# Altium schematic sheet Micro.SchDoc
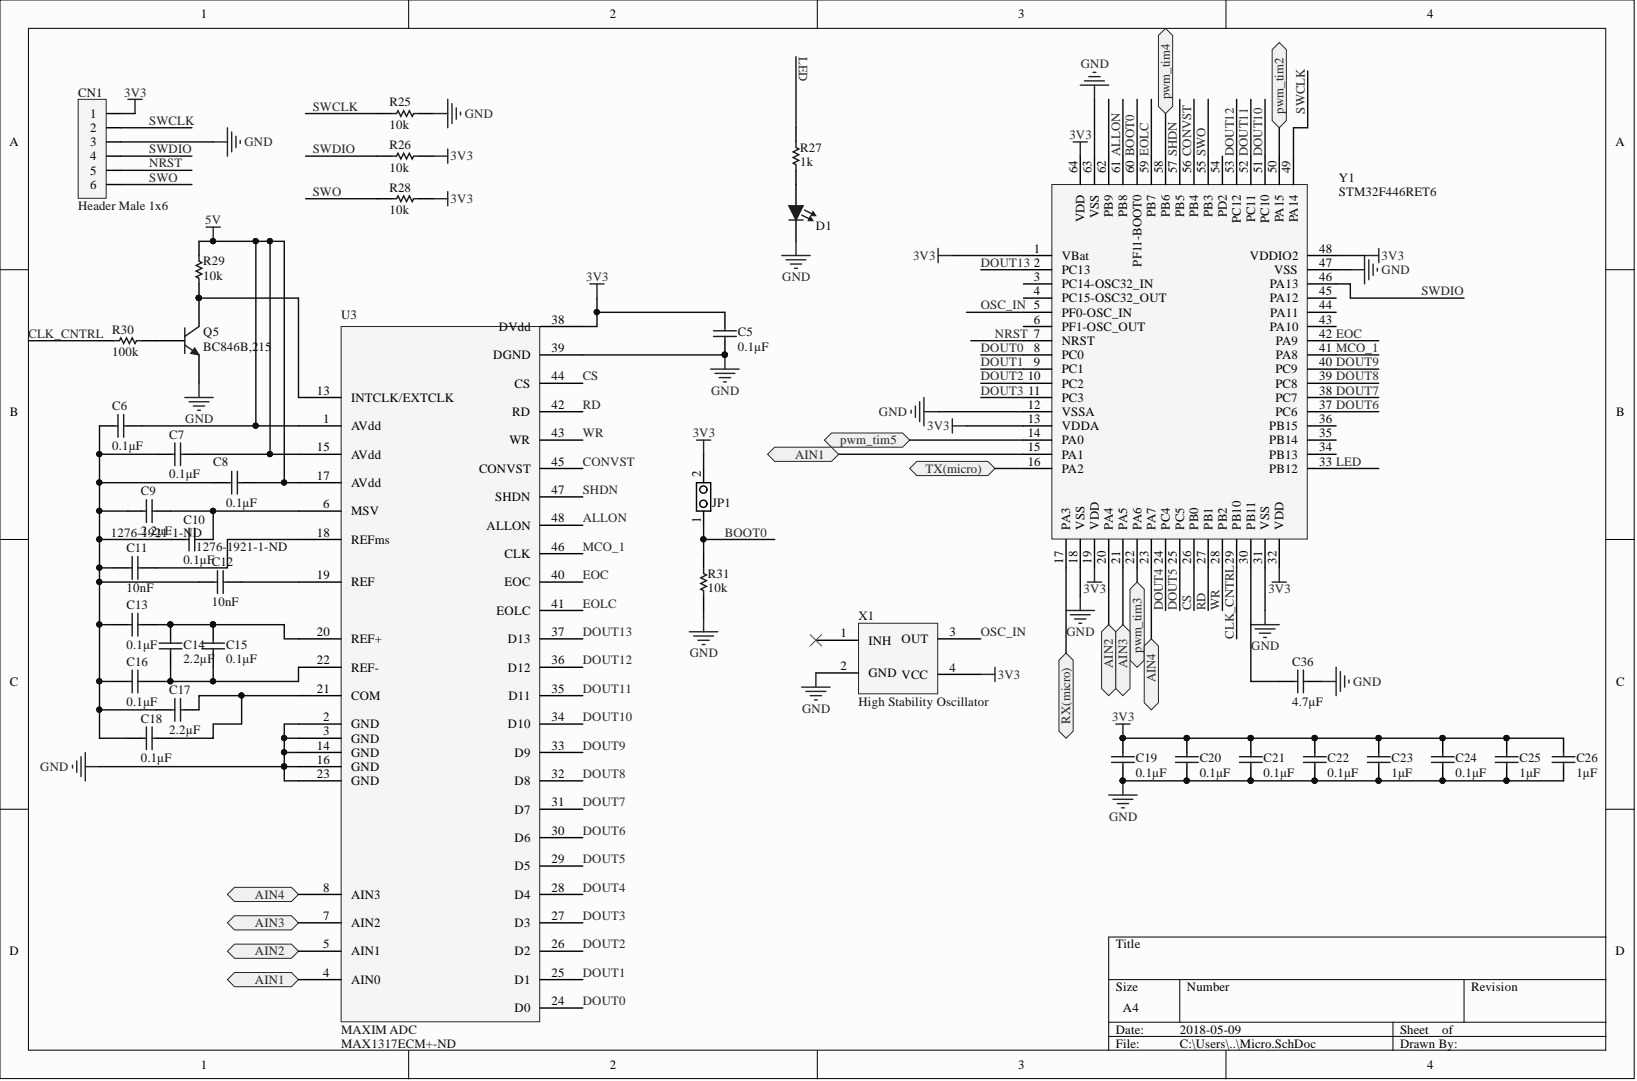
[diagram p0: Micro.SchDoc]

## Parts
C5  0.1μF  [CAPC1608N(0603")]
C6  0.1μF  [CAPC1608N(0603")]
C7  0.1μF  [CAPC1608N(0603")]
C8  0.1μF  [CAPC1608N(0603")]
C9  2.2µF  [CAPC1608N(0603")]
C10  0.1μF  [CAPC1608N(0603")]
C11  10nF  Supplier Part Number 1: 1276-1921-1-ND  [CAPC1608N(0603")]
C12  10nF  Supplier Part Number 1: 1276-1921-1-ND  [CAPC1608N(0603")]
C13  0.1μF  [CAPC1608N(0603")]
C14  2.2µF  [CAPC1608N(0603")]
C15  0.1μF  [CAPC1608N(0603")]
C16  0.1μF  [CAPC1608N(0603")]
C17  2.2µF  [CAPC1608N(0603")]
C18  0.1μF  [CAPC1608N(0603")]
C19  0.1μF  [CAPC1608N(0603")]
C20  0.1μF  [CAPC1608N(0603")]
C21  0.1μF  [CAPC1608N(0603")]
C22  0.1μF  [CAPC1608N(0603")]
C23  1μF  [CAPC1608N(0603")]
C24  0.1μF  [CAPC1608N(0603")]
C25  1μF  [CAPC1608N(0603")]
C26  1μF  [CAPC1608N(0603")]
C36  4.7μF  [CAPC1608N(0603")]
CN1  Header Male 1x6  [Header, Male, 1X6]
D1  Red  [LED0603]  (value not drawn)
JP1  1X2 Pin Head  [2X1 Males Header]  (value not drawn)
Q5  BC846B,215  [SOT-23]
R25  10k  [RESC1608N(0603")]
R26  10k  [RESC1608N(0603")]
R27  1k  [RESC1608N(0603")]
R28  10k  [RESC1608N(0603")]
R29  10k  [RESC1608N(0603")]
R30  100k  [RESC1608N(0603")]
R31  10k  [RESC1608N(0603")]
U3  MAXIM ADC  Supplier Part Number 1: MAX1317ECM+-ND  [LQFP-48]
X1  High Stability Oscillator  [KC2520C-C2 SOP]
Y1  STM32F446RET6  [STM-LQFP64_M]

## Ports
AIN1, AIN2, AIN3, AIN4, pwm_tim2, pwm_tim3, pwm_tim4, pwm_tim5, RX(micro), TX(micro)

## Nets
3V3: C5.2 C19.2 C20.2 C21.2 C22.2 C23.2 C24.2 C25.2 C26.2 CN1.1 JP1.2 R26.2 R28.2 U3.38(DVdd) X1.4(VCC) Y1.1(VBat) Y1.13(VDDA) Y1.19(VDD) Y1.32(VDD) Y1.48(VDDIO2) Y1.64(VDD)
5V: C6.2 C7.2 C8.2 R29.2 U3.1(AVdd) U3.15(AVdd) U3.17(AVdd)
GND: C5.1 C19.1 C20.1 C21.1 C22.1 C23.1 C24.1 C25.1 C26.1 C36.2 CN1.3 D1.1 Q5.2 R25.1 R31.1 U3.2(GND) U3.3(GND) U3.14(GND) U3.16(GND) U3.23(GND) U3.39(DGND) X1.2(GND) Y1.12(VSSA) Y1.18(VSS) Y1.31(VSS) Y1.47(VSS) Y1.63(VSS)
AIN1: U3.4(AIN0) Y1.15(PA1) ; port AIN1
AIN2: U3.5(AIN1) Y1.20(PA4) ; port AIN2
AIN3: U3.7(AIN2) Y1.21(PA5) ; port AIN3
AIN4: U3.8(AIN3) Y1.23(PA7) ; port AIN4
ALLON: U3.48(ALLON) Y1.61(PB8)
BOOT0: JP1.1 R31.2 Y1.60(PF11-BOOT0)
CLK_CNTRL: R30.1 Y1.29(PB10)
CONVST: U3.45(CONVST) Y1.56(PB4)
CS: U3.44(CS) Y1.26(PB0)
DOUT0: U3.24(D0) Y1.8(PC0)
DOUT1: U3.25(D1) Y1.9(PC1)
DOUT2: U3.26(D2) Y1.10(PC2)
DOUT3: U3.27(D3) Y1.11(PC3)
DOUT4: U3.28(D4) Y1.24(PC4)
DOUT5: U3.29(D5) Y1.25(PC5)
DOUT6: U3.30(D6) Y1.37(PC6)
DOUT7: U3.31(D7) Y1.38(PC7)
DOUT8: U3.32(D8) Y1.39(PC8)
DOUT9: U3.33(D9) Y1.40(PC9)
DOUT10: U3.34(D10) Y1.51(PC10)
DOUT11: U3.35(D11) Y1.52(PC11)
DOUT12: U3.36(D12) Y1.53(PC12)
DOUT13: U3.37(D13) Y1.2(PC13)
EOC: U3.40(EOC) Y1.42(PA9)
EOLC: U3.41(EOLC) Y1.59(PB7)
LED: R27.2 Y1.33(PB12)
MCO_1: U3.46(CLK) Y1.41(PA8)
NRST: CN1.5 Y1.7(NRST)
OSC_IN: X1.3(OUT) Y1.5(PF0-OSC_IN)
pwm_tim2: Y1.50(PA15) ; port pwm_tim2
pwm_tim3: Y1.22(PA6) ; port pwm_tim3
pwm_tim4: Y1.58(PB6) ; port pwm_tim4
pwm_tim5: Y1.14(PA0) ; port pwm_tim5
RD: U3.42(RD) Y1.27(PB1)
RX(micro): Y1.17(PA3) ; port RX(micro)
SHDN: U3.47(SHDN) Y1.57(PB5)
SWCLK: CN1.2 R25.2 Y1.49(PA14)
SWDIO: CN1.4 R26.1 Y1.46(PA13)
SWO: CN1.6 R28.1 Y1.55(PB3)
TX(micro): Y1.16(PA2) ; port TX(micro)
WR: U3.43(WR) Y1.28(PB2)
NetC6_1: C6.1 C7.1 C8.1 C9.1 C10.1 C11.1 C12.1 C13.2 C16.2 C17.1 C18.1
NetC9_2: C9.2 C10.2 U3.6(MSV)
NetC11_2: C11.2 U3.18(REFms)
NetC12_2: C12.2 U3.19(REF)
NetC13_1: C13.1 C14.2 C15.2 U3.20(REF+)
NetC14_1: C14.1 C15.1 C16.1 U3.22(REF-)
NetC17_2: C17.2 C18.2 U3.21(COM)
NetC36_1: C36.1 Y1.30(PB11)
NetD1_2: D1.2 R27.1
NetQ5_1: Q5.1 R30.2
NetQ5_3: Q5.3 R29.1 U3.13(INTCLK/EXTCLK)

Not connected: X1 1(INH); Y1 3(PC14-OSC32_IN) 4(PC15-OSC32_OUT) 6(PF1-OSC_OUT) 34(PB13) 35(PB14) 36(PB15) 43(PA10) 44(PA11) 45(PA12) 54(PD2) 62(PB9)

## Library data of the parts
C5-C8 C10 C13 C15 C16 C18-C22 C24 = 0.1uF 100V 0603 SMD, Ceramic: Capacitor, Ceramic, 100V, +/- 10%, 0603 SMD, X7R; MFG: TDK; MFG#: CGA3E3X7S2A104K080AB; Component Kind: Standard; PackageDescription: Chip Capacitor; Published: 16-04-26; Supplier 1: Digi-Key; Supplier Part Number 1: 445-6938-1-ND; Value: 0.1μF; Created By: Ashwin Sira; Library Name: AUVic_Integrated_Library_Capacitors.IntLib; Publisher: AUVic
C9 C14 C17 = 2.2uF, 50V, 0603 SMD, X5R, Ceramic: Capacitor, Ceramic, 50V, 10%, 0603 SMD, X5R; Component Kind: Standard; PackageDescription: Chip Capacitor; Supplier 1: Digi-Key; Supplier Part Number 1: 490-10733-1-ND; Value: 2.2µF; Library Name: AUVic_Integrated_Library_Capacitors.IntLib; Publisher: AUVic
C11 C12 = 10 nF, 50V 0603 SMD, Ceramic: Capacitor, Ceramic, 50V, +/- 10%, 0603 SMD; MFG: Samsung; MFG#: GRM188R61A475KE15D; Component Kind: Standard; PackageDescription: Chip Capacitor; Published: 16-06-23; Supplier 1: Digi-Key; Value: 10nF; Created By: Gabriel; Library Name: AUVic_Integrated_Library_Capacitors.IntLib; Publisher: AUVic
C23 C25 C26 = 1uF 25V 0603 SMD, Ceramic: Capacitor, Ceramic, 25V, +/- 10%, 0603 SMD, X5R; MFG: Murata-Electronics-North-America; MFG#: GRM188R61E105KA12D; Component Kind: Standard; PackageDescription: Chip Capacitor; Published: 16-06-27; Supplier 1: Digi-Key; Supplier Part Number 1: 490-3897-1-ND; Value: 1μF; Created By: Andy Bates; Library Name: AUVic_Integrated_Library_Capacitors.IntLib; Publisher: AUVic
C36 = 4.7uF 10V 0603 SMD, Ceramic: Capacitor, Ceramic, 10V, +/- 10%, 0603 SMD, X5R; MFG: Samsung; MFG#: GRM188R61A475KE15D; Component Kind: Standard; PackageDescription: Chip Capacitor; Published: 16-06-23; Supplier 1: Digi-Key; Supplier Part Number 1: 490-10477-1-ND; Value: 4.7μF; Created By: Ashwin Sira; Library Name: AUVic_Integrated_Library_Capacitors.IntLib; Publisher: AUVic
CN1 = Header, Male, 1x6: 1x6, Pads Pitch: 0.1" (2.54mm), Through hole; Made By: Andy Bates; Component Kind: Standard; Supplier 1: Digi-Key; Supplier Part Number 1: 952-2270-ND; Library Name: AUVic_Schematic_Library_Connectors.SchLib
D1 = LED, 0805 (2012), Red, Clear: Colour: Red; Component Kind: Standard; Description: LED, 0805 (2012), Red, Clear; Supplier 1: Digi-Key; Supplier Part Number 1: 732-4984-1-ND; Created By: Ashwin Sira; Library Name: AUVic_Integrated_Library_Diodes.IntLib
JP1 = 1x2 Male Header: Made By: Andy Bates; Component Kind: Standard; Supplier 1: Digi-Key; Supplier Part Number 1: 952-3073-ND; Library Name: AUVic_Schematic_Library_Connectors.SchLib
Q5 = BC846B,215: NPN 65V 100mA 250mW; Component Kind: Standard; DatasheetVersion: 1997; LatestRevisionDate: 09-Sep-2016; Published: 8-Jun-2000; Supplier 1: Digi-Key; Supplier Part Number 1: 568-1632-1-ND; Library Name: AUVic_Integrated_Library_Transistors.IntLib; Publisher: Andy Bates
R25 R26 R28 R29 R31 = 10kR, 1%, 1/10W, 0603 SMD: Made By: Ashwin Sira; Owned By: AUVic; Component Kind: Standard; Created On: 14-05-31; Description: 10kR, 1%, 1/10W, 0603 SMD; Last Edited: 14-05-31; Library Name: AUVic_Integrated_Library_Resistors.IntLib; Supplier 1: Digi-Key; Supplier Part Number 1: 311-10.0KHRCT-ND; Value: 10k
R27 = 1kR, 1%, 1/10W , 0603, SMD: Component Kind: Standard; Date Created: 2017-05-10; Description: 1kR, 1%, 1/10W , 0603, SMD; Supplier 1: Digikey; Value: 1k; Created By: Andy Bates; Library Name: AUVic_Integrated_Library_Resistors.IntLib; Publisher: AUVic
R30 = 100kR, 1%, 1/10W, 0603 SMD: Made By: Andy Bates; Owned By: AUVic; Component Kind: Standard; Created On: 14-06-30; Description: 100kR, 1%, 1/10W, 0603 SMD; Last Edited: 14-06-30; Library Name: AUVic_Integrated_Library_Resistors.IntLib; Supplier 1: Digi-Key; Supplier Part Number 1: 311-100KGRCT-ND; Value: 100k
U3 = MAX1317ECM+: Simultaneous-Sampling ADC; Component Kind: Standard; Supplier 1: Digi-Key; Created by: Gabriel; Library Name: AUVic_Schematic_Library_Sensors.SchLib
X1 = Clock Oscillator 26MHz: Component Kind: Standard; Supplier 1: Digi-Key; Supplier Part Number 1: 478-6305-1-ND; Created by: Gabriel; Library Name: AUVic_Integrated_Library_Oscillators.IntLib
Y1 = STM32F4446RET6: ARM Cortex-M4 32-bit MCU, 180 MHz, 128 KB Internal Rap, 50 I/O, 64-pin LQFP, -40 to 85 degC, Tray; Core Speed: 180 MHz; Component Kind: Standard; Core Size: 32-bit; Supplier: Digikey; Supplier Part No: 497-15376-ND; Library Name: AUVic_Integrated_Library_Microprocessors.IntLib
